# Altium schematic sheet [03] - SCHEMATIC.SchDoc
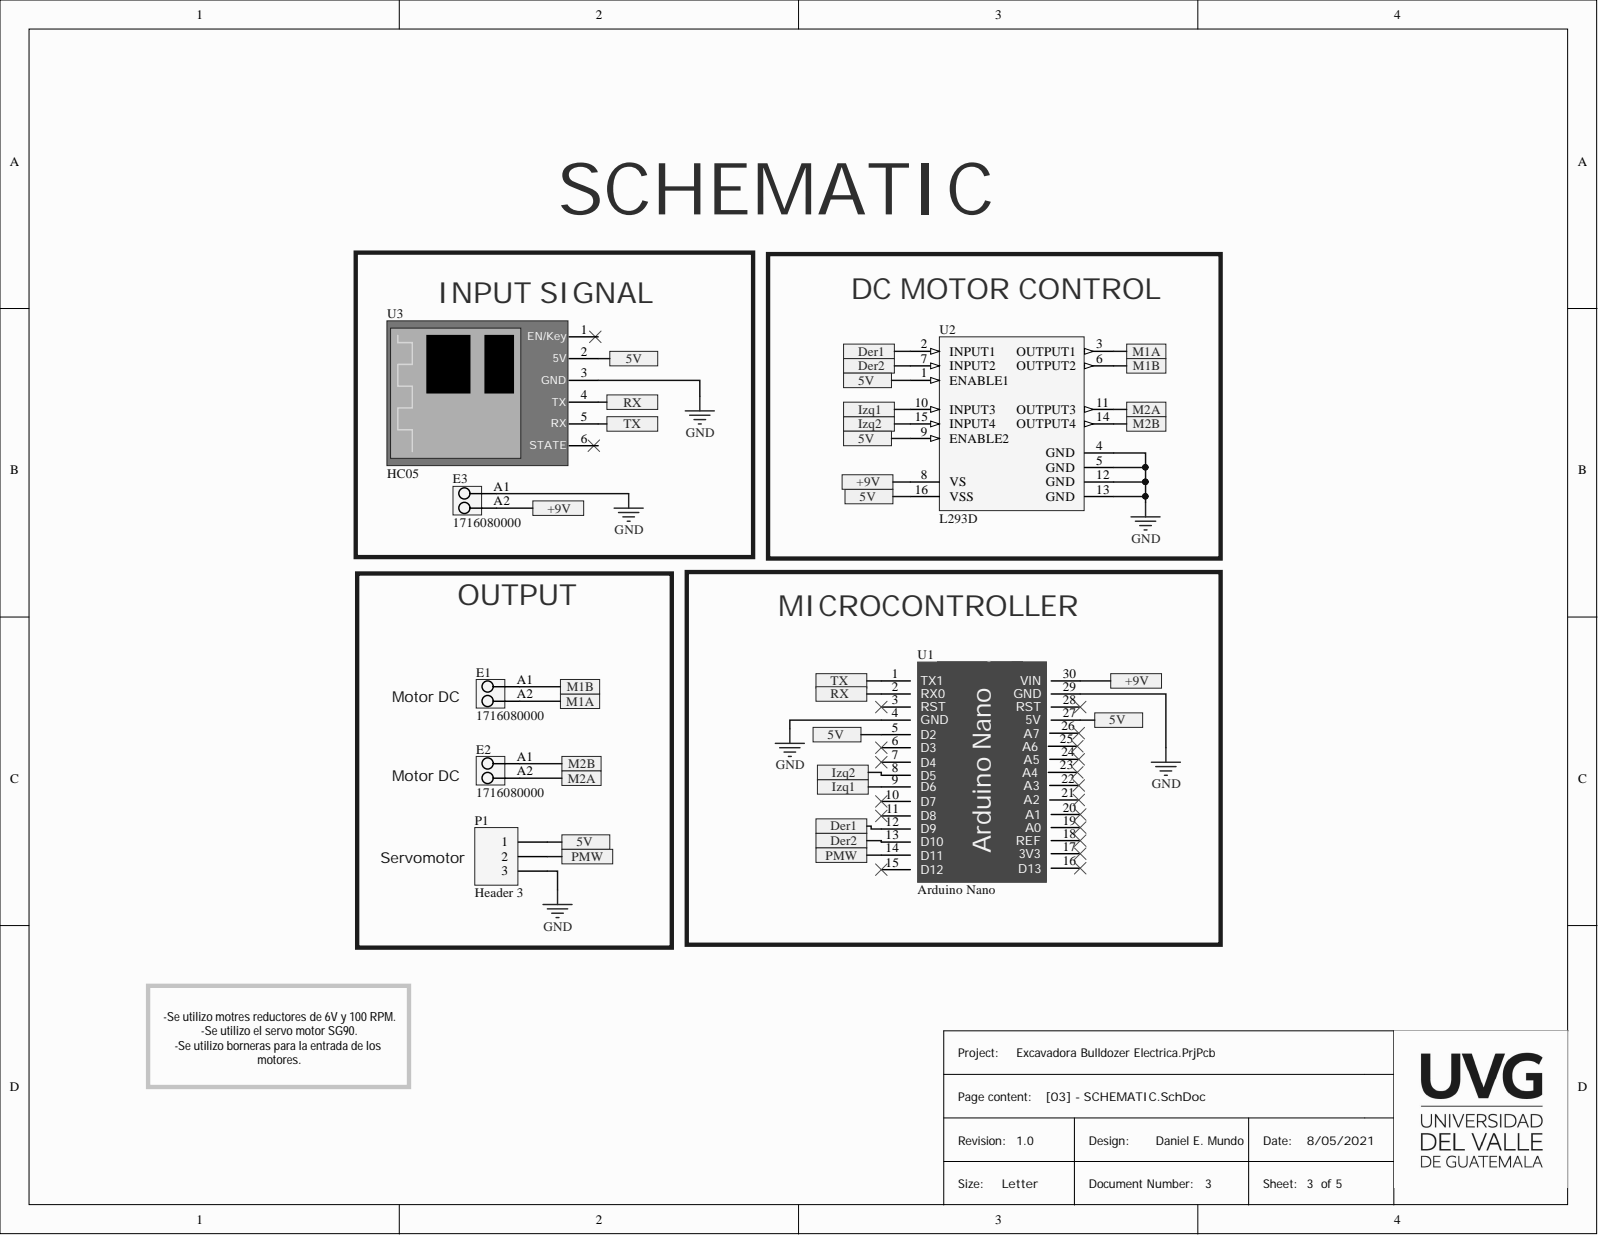
[diagram p0: [03] - SCHEMATIC.SchDoc]

## Parts
E1  1716080000  [LM_5_08_90_02]
E2  1716080000  [LM_5_08_90_02]
E3  1716080000  [LM_5_08_90_02]
P1  Header 3  [HDR1X3]
U1  Arduino Nano  Manufacturer: Arduino  [Arduino Nano]
U2  L293D  [STM-L293D-16]
U3  HC05  [HC05]

## Ports
5V, +9V, Der1, Der2, Izq1, Izq2, M1A, M1B, M2A, M2B, PMW, RX, TX

## Nets
GND: E3.A1 P1.3 U1.4(GND) U1.29(GND) U2.4(GND) U2.5(GND) U2.12(GND) U2.13(GND) U3.3(GND)
5V: P1.1 U1.5(D2) U1.27(5V) U2.1(ENABLE1) U2.9(ENABLE2) U2.16(VSS) U3.2(5V) ; port 5V
+9V: E3.A2 U1.30(VIN) U2.8(VS) ; port +9V
Der1: U1.12(D9) U2.2(INPUT1) ; port Der1
Der2: U1.13(D10) U2.7(INPUT2) ; port Der2
Izq1: U1.9(D6) U2.10(INPUT3) ; port Izq1
Izq2: U1.8(D5) U2.15(INPUT4) ; port Izq2
M1A: E1.A2 U2.3(OUTPUT1) ; port M1A
M1B: E1.A1 U2.6(OUTPUT2) ; port M1B
M2A: E2.A2 U2.11(OUTPUT3) ; port M2A
M2B: E2.A1 U2.14(OUTPUT4) ; port M2B
PMW: P1.2 U1.14(D11) ; port PMW
RX: U1.2(RX0) U3.4(TX) ; port RX
TX: U1.1(TX1) U3.5(RX) ; port TX

Not connected: U1 3(RST) 6(D3) 7(D4) 10(D7) 11(D8) 15(D12) 16(D13) 17(3V3) 18(REF) 19(A0) 20(A1) 21(A2) 22(A3) 23(A4) 24(A5) 25(A6) 26(A7) 28(RST); U3 1(EN/Key) 6(STATE)

## Library data of the parts
E1-E3 = CMP-1559-01766-1: OMNIMATE Signal - series LM; Manufacturer: Weidmueller; Contact_material: Cu-Leg; Contact_surface: tinned; Insulating_material: Wemid (PA); Mounting_onto_the_PCB: Solder connection; No_of_poles: 2; Pitch_inch: 0,2 inch; Pitch_mm: 5,08 mm; Rated_current_use_group_B_UL_1059: 15 A; Rated_voltage_for_surge_voltage_class_pollution_degree_II_2: 630 V; Rated_voltage_use_group_B_UL_1059: 300 V; Short_text_for_material: LM 5.08/02/90 3.5SN OR BX; Side_termination_characteristic: closed side; Solder_pin_length_mm: 3,5 mm; Solid_max_H05_07_V_U: 2,5 mm²; Solid_min_H05_07_V_U: 0,2 mm²; UL_94_flammability_rating: V-0; Wire_cross_section_AWG_max_UL_1059: AWG 14; Wire_cross_section_AWG_min_UL_1059: AWG 24; Colour: Orange; Component Kind: Standard; ComponentLink1Description: Manufacturer URL; ComponentLink1URL: http://www.weidmueller.com/; ComponentLink2Description: URL Link1; ComponentLink2URL: http://catalog.weidmueller.com/catalog/Start.do?localeId=en&ObjectID=1716080000; PackageReference: LM_5_08_90_02; Packaging: Box; PartNumber: 1716080000; Qty: 500 PCS; Revision: V1; Library Name: Components_Bornera.IntLib
P1 = Header 3: Header, 3-Pin; Component Kind: Standard; LatestRevisionDate: 17-Jul-2002; LatestRevisionNote: Re-released for DXP Platform.; Library Name: Miscellaneous Connectors.IntLib; Signal Integrity: Connector; Publisher: Altium Limited
U1 = Arduino Nano: ARDUINO - A000005 - Development Board, Arduino Nano, ATmega328 MCU, 14 3.3V I/O, 6 PWM Outputs, USB Mini B; Core Architecture: AVR; Data Bus Width: 8b; Evaluation Kit: Yes; Interface: I2C,SPI,USB; Number of Bits: 8; Operating Supply Voltage: 12V; Component Kind: Standard; ComponentLink1Description: https://datasheet.ciiva.com/2398/arduinonanomanual23-2398236.pdf?src-supplier=Digi-Key; ComponentLink1URL: https://datasheet.ciiva.com/2398/arduinonanomanual23-2398236.pdf?src-supplier=Digi-Key; ComponentLink2Description: https://datasheet.ciiva.com/4926/arduino-arduinoboardnano-188748-4926316.pdf?src-supplier=Mouser; ComponentLink2URL: https://datasheet.ciiva.com/4926/arduino-arduinoboardnano-188748-4926316.pdf?src-supplier=Mouser; ComponentLink3Description: https://datasheet.ciiva.com/20168/73-4448-20168542.pdf?src-supplier=Rapid+Electronics; ComponentLink3URL: https://datasheet.ciiva.com/20168/73-4448-20168542.pdf?src-supplier=Rapid+Electronics; ComponentLink4Description: https://datasheet.ciiva.com/19455/2121148-19455443.pdf?src-supplier=Jameco; ComponentLink4URL: https://datasheet.ciiva.com/19455/2121148-19455443.pdf?src-supplier=Jameco; ComponentLink5Description: https://datasheet.ciiva.com/1414/810078-1414124.pdf?src-supplier=Newark; ComponentLink5URL: https://datasheet.ciiva.com/1414/810078-1414124.pdf?src-supplier=Newark; ComponentLink6Description: https://datasheet.ciiva.com/1336/1682238-1336473.pdf?src-supplier=Element14; ComponentLink6URL: https://datasheet.ciiva.com/1336/1682238-1336473.pdf?src-supplier=Element14; Height: 0.25inch; Length: 7inch; Library Name: Arduino.IntLib; Manufacturer Part Number: A000005; Package Quantity: 50; Packaging: Bulk; Pricing 1: 1 = 22.00000, 10 = 20.90000, 100 = 19.80010, 500 = 18.70012 (USD); REACH SVHC: No SVHC; RoHS: Yes; Stock 1: 7864; Supplier 1: Digi-Key; Supplier Part Number 1: 1050-1001-ND; Weight: 0.358383oz; Width: 5inch
U2 = CMP-2000-06524-1: Push-Pull Four Channel Driver with Diode, 4.5 to 36 V, -40 to 150 degC, 16-Pin PDIP, RoHS, Tube; Manufacturer: STMicroelectronics; Current - Output / Channel: 600mA; Current - Peak Output: 1.2A; Dynamic Catalog: HalfBridge; Input Type: Non-Inverting; Number of Outputs: 4; Other Names: 497-2936-5; Other Related Documents: L293DViewAllSpecifications; Voltage - Supply: 4.5V~36V; Category: IntegratedCircuits(ICs); Component Kind: Standard; Datasheet URL: http://www.st.com/st-web-ui/static/active/en/resource/technical/document/datasheet/CD00000059.pdf; Datasheet Version: 07/2003; Family: PMIC-MOSFET,BridgeDrivers-InternalSwitch; Library Name: Components - 23.04.21 - 17.26.IntLib; Manufacturer URL: http://www.st.com/; Mounting Technology: ThroughHole; Mounting Type: ThroughHole; On-State Resistance: -; Operating Temperature: -40°C~150°C; Package / Case: 16-DIP(0.300",7.62mm); Package Description: 16-Pin Thru-Hole Dual-In-Line, Body 20 x 6.85 mm, Pitch 2.54 mm; Package Reference: DIP-16; Packaging: Tube; PartNumber: L293D; Series: -; Standard Package: 25; Supplier Device Package: 16-PowerDIP; Type: HalfBridge
U3 = HC05B2800000G: Manufacturer: Amphenol; Current: 2A; Number of Levels: 1; Number of Positions Per Level: 5; Voltage: 300V; Wire Gauge (Max): 26AWG; Wire Gauge (Min): 20AWG; Color: Grey; Component Kind: Standard; Contact Plating: Bronze,Tin; Housing Material: Thermoplastic; Library Name: Arduino.IntLib; Manufacturer Part Number: HC05B2800000G; Max Operating Temperature: 115°C; Min Operating Temperature: -40°C; Mount: Through Hole; Packaging: Bulk; Pricing 1: 1 = 0.67000, 10 = 0.61700, 50 = 0.57000, 100 = 0.56700, 1000 = 0.40400, 10000 = 0.32100 (USD); RoHS: Yes; RoHS Compliant: Yes; Stock 1: 1975; Supplier 1: Mouser; Supplier Part Number 1: 649-HC05B28000J0G; Termination: Screwless
